# Altium schematic sheet Ti60-F225_Button_Board.SchDoc
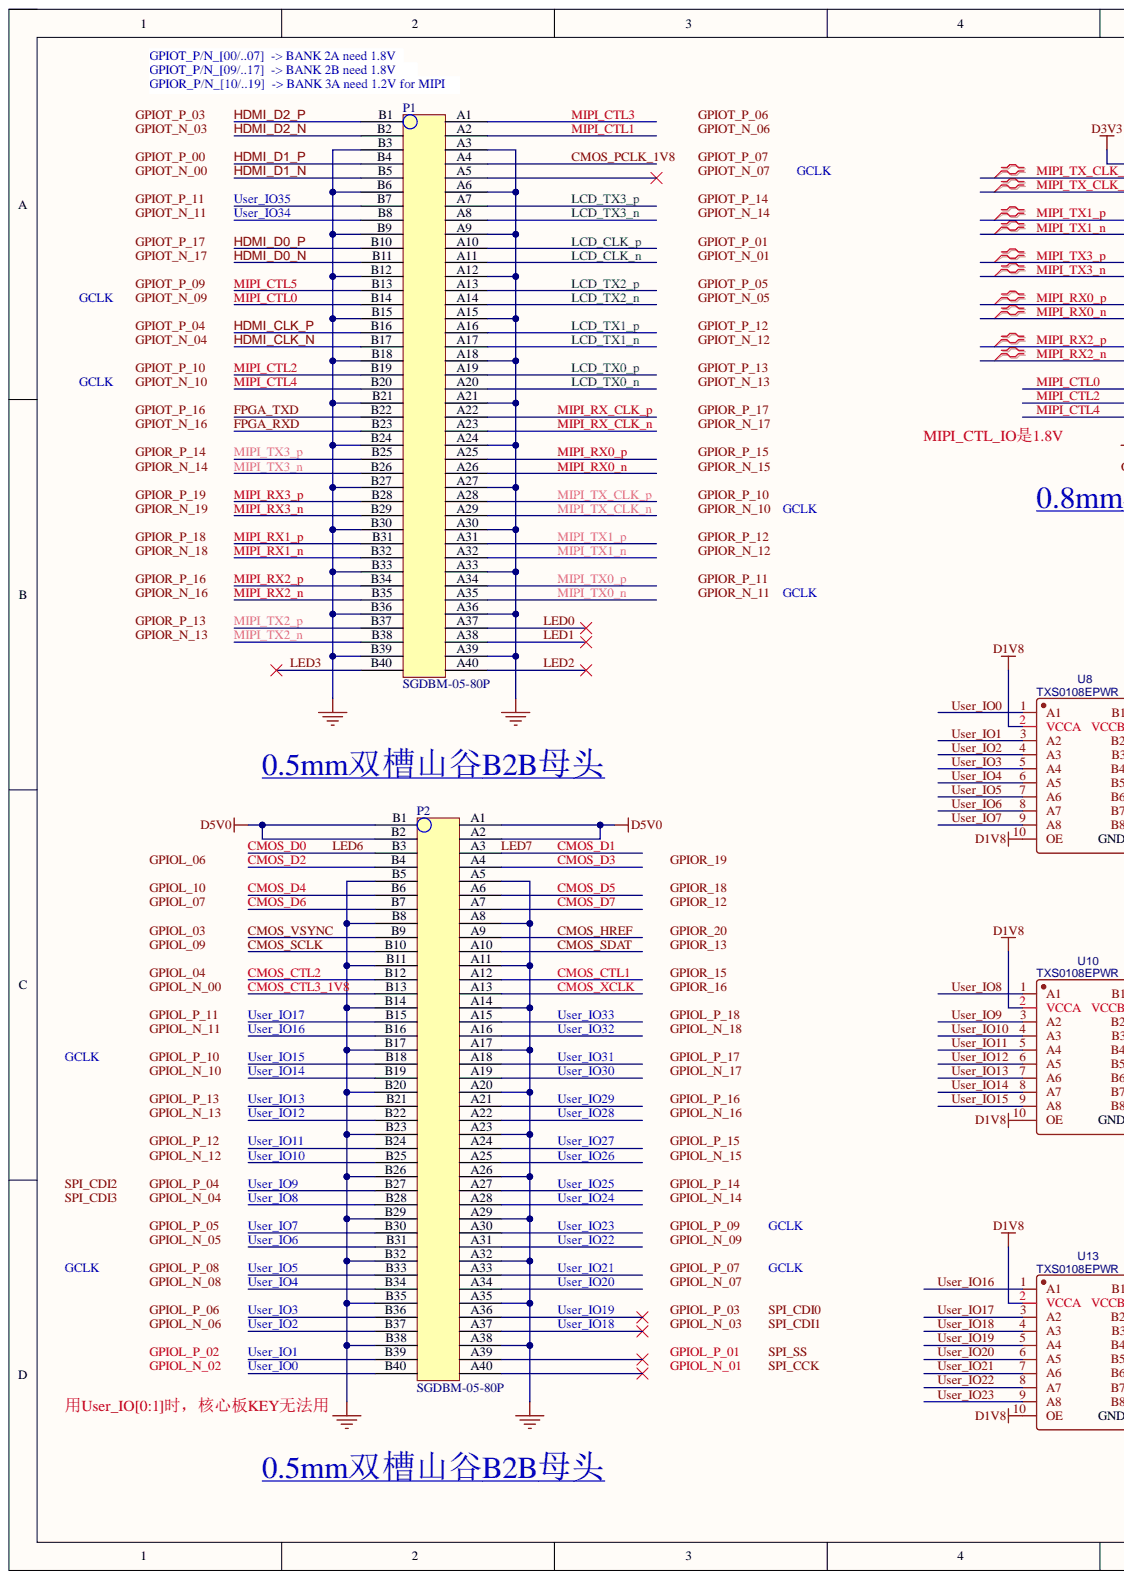
[diagram p0: Ti60-F225_Button_Board.SchDoc, left]
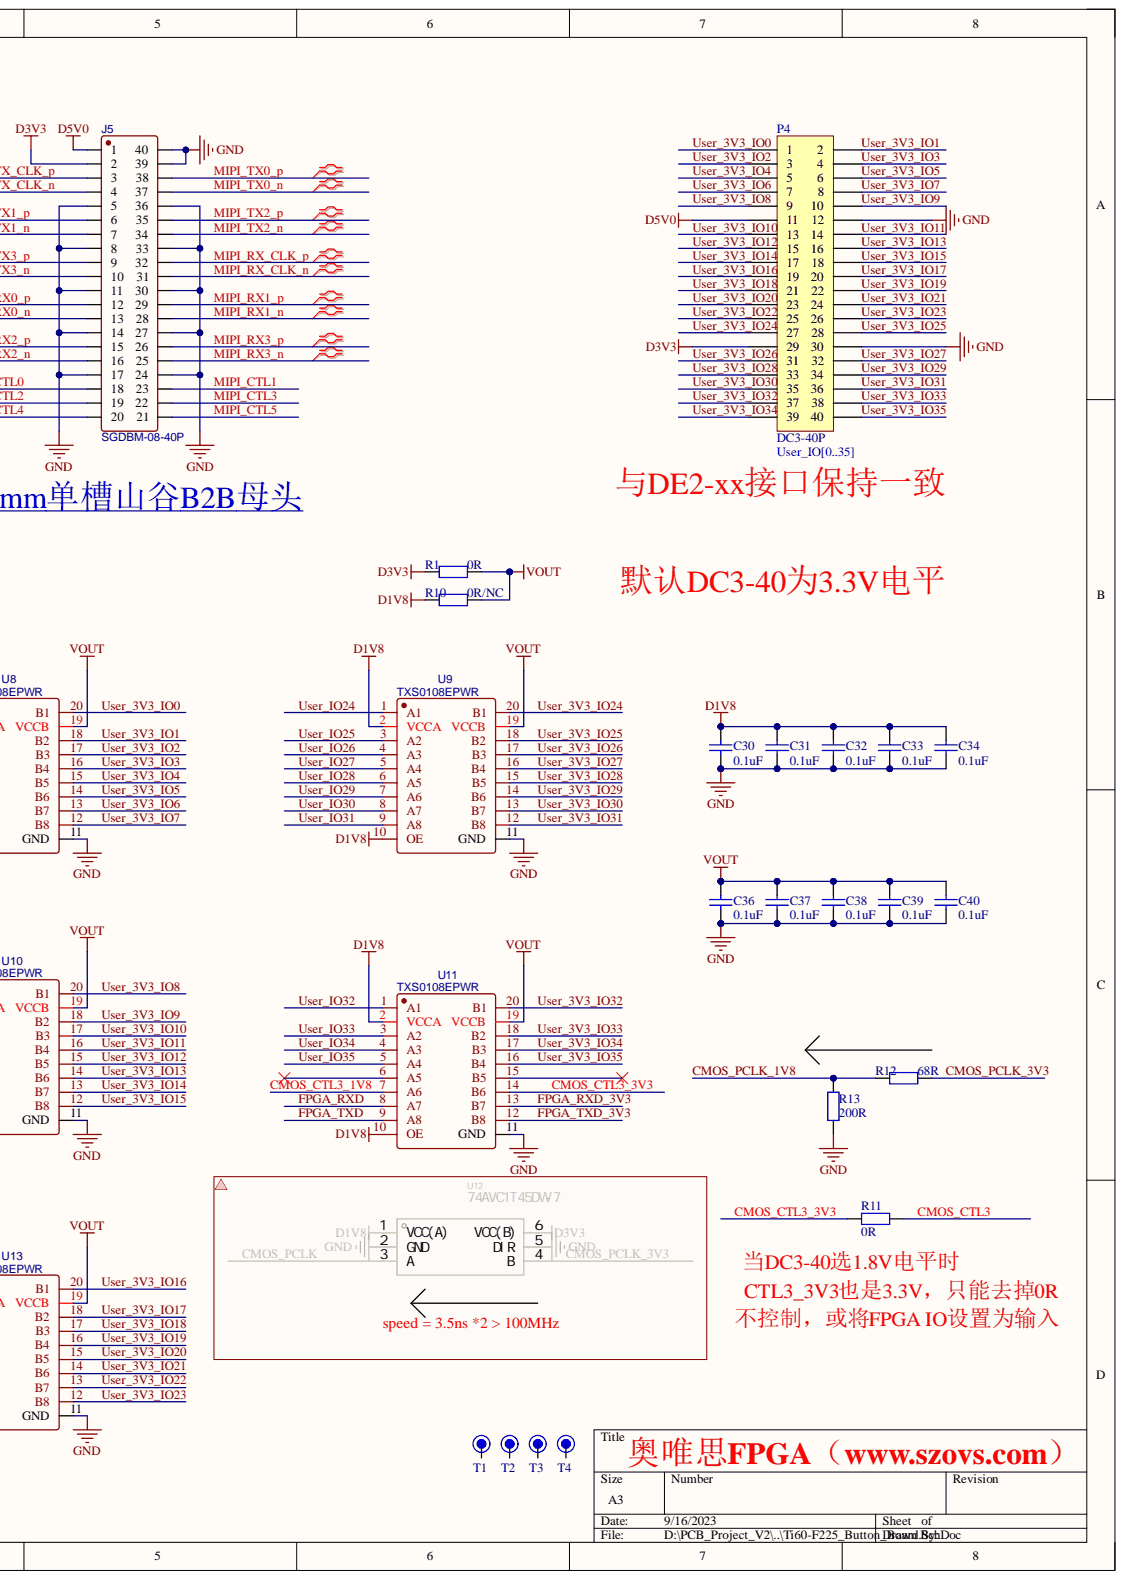
[diagram p1: Ti60-F225_Button_Board.SchDoc, right]

## Parts
C30  0.1uF  [R0402]
C31  0.1uF  [R0402]
C32  0.1uF  [R0402]
C33  0.1uF  [R0402]
C34  0.1uF  [R0402]
C36  0.1uF  [R0402]
C37  0.1uF  [R0402]
C38  0.1uF  [R0402]
C39  0.1uF  [R0402]
C40  0.1uF  [R0402]
J5  SGDBM-08-40P  [SGDBM-08-40P]
P1  SGDBM-05-80P  [P2P_0_5MM_80P]
P2  SGDBM-05-80P  [P2P_0_5MM_80P]
P4  DC3-40P  [DC3-40]
R1  0R  [R0603]
R10  0R/NC  [R0603]
R11  0R  [R0603]
R12  68R  [R0402]
R13  200R  [R0402]
T1  Pin_M3  [Pin_M3]  (value not drawn)
T2  Pin_M3  [Pin_M3]  (value not drawn)
T3  Pin_M3  [Pin_M3]  (value not drawn)
T4  Pin_M3  [Pin_M3]  (value not drawn)
U8  TXS0108EPWR  [TSSOP20]
U9  TXS0108EPWR  [TSSOP20]
U10  TXS0108EPWR  [TSSOP20]
U11  TXS0108EPWR  [TSSOP20]
U13  TXS0108EPWR  [TSSOP20]

## Nets
D1V8: C30.2 C31.2 C32.2 C33.2 C34.2 R10.2 U8.2(VCCA) U8.10(OE) U9.2(VCCA) U9.10(OE) U10.2(VCCA) U10.10(OE) U11.2(VCCA) U11.10(OE) U13.2(VCCA) U13.10(OE)
D3V3: J5.2 P4.29 R1.2
D5V0: J5.1 P2.A1 P2.A2 P2.B1 P2.B2 P4.11
GND: C30.1 C31.1 C32.1 C33.1 C34.1 C36.1 C37.1 C38.1 C39.1 C40.1 J5.5 J5.8 J5.11 J5.14 J5.17 J5.24 J5.27 J5.30 J5.33 J5.36 J5.39 J5.40 P1.A3 P1.A6 P1.A9 P1.A12 P1.A15 P1.A18 P1.A21 P1.A24 P1.A27 P1.A30 P1.A33 P1.A36 P1.A39 P1.B3 P1.B6 P1.B9 P1.B12 P1.B15 P1.B18 P1.B21 P1.B24 P1.B27 P1.B30 P1.B33 P1.B36 P1.B39 P2.A5 P2.A8 P2.A11 P2.A14 P2.A17 P2.A20 P2.A23 P2.A26 P2.A29 P2.A32 P2.A35 P2.A38 P2.B5 P2.B8 P2.B11 P2.B14 P2.B17 P2.B20 P2.B23 P2.B26 P2.B29 P2.B32 P2.B35 P2.B38 P4.12 P4.30 R13.2 U8.11(GND) U9.11(GND) U10.11(GND) U11.11(GND) U13.11(GND)
VOUT: C36.2 C37.2 C38.2 C39.2 C40.2 R1.1 R10.1 U8.19(VCCB) U9.19(VCCB) U10.19(VCCB) U11.19(VCCB) U13.19(VCCB)
CMOS_CTL1: P2.A12
CMOS_CTL2: P2.B12
CMOS_CTL3: R11.2
CMOS_CTL3_1V8: P2.B13 U11.7(A6)
CMOS_CTL3_3V3: R11.1 U11.14(B6)
CMOS_D0: P2.B3
CMOS_D1: P2.A3
CMOS_D2: P2.B4
CMOS_D3: P2.A4
CMOS_D4: P2.B6
CMOS_D5: P2.A6
CMOS_D6: P2.B7
CMOS_D7: P2.A7
CMOS_HREF: P2.A9
CMOS_PCLK_1V8: P1.A4 R12.2 R13.1
CMOS_PCLK_3V3: R12.1
CMOS_SCLK: P2.B10
CMOS_SDAT: P2.A10
CMOS_VSYNC: P2.B9
CMOS_XCLK: P2.A13
FPGA_RXD: P1.B23 U11.8(A7)
FPGA_RXD_3V3: U11.13(B7)
FPGA_TXD: P1.B22 U11.9(A8)
FPGA_TXD_3V3: U11.12(B8)
HDMI_CLK_N: P1.B17
HDMI_CLK_P: P1.B16
HDMI_D0_N: P1.B11
HDMI_D0_P: P1.B10
HDMI_D1_N: P1.B5
HDMI_D1_P: P1.B4
HDMI_D2_N: P1.B2
HDMI_D2_P: P1.B1
LCD_CLK_n: P1.A11
LCD_CLK_p: P1.A10
LCD_TX0_n: P1.A20
LCD_TX0_p: P1.A19
LCD_TX1_n: P1.A17
LCD_TX1_p: P1.A16
LCD_TX2_n: P1.A14
LCD_TX2_p: P1.A13
LCD_TX3_n: P1.A8
LCD_TX3_p: P1.A7
LED0: P1.A37
LED1: P1.A38
LED2: P1.A40
LED3: P1.B40
MIPI_CTL0: J5.18 P1.B14
MIPI_CTL1: J5.23 P1.A2
MIPI_CTL2: J5.19 P1.B19
MIPI_CTL3: J5.22 P1.A1
MIPI_CTL4: J5.20 P1.B20
MIPI_CTL5: J5.21 P1.B13
MIPI_RX0_n: J5.13 P1.A26
MIPI_RX0_p: J5.12 P1.A25
MIPI_RX1_n: J5.28 P1.B32
MIPI_RX1_p: J5.29 P1.B31
MIPI_RX2_n: J5.16 P1.B35
MIPI_RX2_p: J5.15 P1.B34
MIPI_RX3_n: J5.25 P1.B29
MIPI_RX3_p: J5.26 P1.B28
MIPI_RX_CLK_n: J5.31 P1.A23
MIPI_RX_CLK_p: J5.32 P1.A22
MIPI_TX0_n: J5.37 P1.A35
MIPI_TX0_p: J5.38 P1.A34
MIPI_TX1_n: J5.7 P1.A32
MIPI_TX1_p: J5.6 P1.A31
MIPI_TX2_n: J5.34 P1.B38
MIPI_TX2_p: J5.35 P1.B37
MIPI_TX3_n: J5.10 P1.B26
MIPI_TX3_p: J5.9 P1.B25
MIPI_TX_CLK_n: J5.4 P1.A29
MIPI_TX_CLK_p: J5.3 P1.A28
User_3V3_IO0: P4.1 U8.20(B1)
User_3V3_IO1: P4.2 U8.18(B2)
User_3V3_IO2: P4.3 U8.17(B3)
User_3V3_IO3: P4.4 U8.16(B4)
User_3V3_IO4: P4.5 U8.15(B5)
User_3V3_IO5: P4.6 U8.14(B6)
User_3V3_IO6: P4.7 U8.13(B7)
User_3V3_IO7: P4.8 U8.12(B8)
User_3V3_IO8: P4.9 U10.20(B1)
User_3V3_IO9: P4.10 U10.18(B2)
User_3V3_IO10: P4.13 U10.17(B3)
User_3V3_IO11: P4.14 U10.16(B4)
User_3V3_IO12: P4.15 U10.15(B5)
User_3V3_IO13: P4.16 U10.14(B6)
User_3V3_IO14: P4.17 U10.13(B7)
User_3V3_IO15: P4.18 U10.12(B8)
User_3V3_IO16: P4.19 U13.20(B1)
User_3V3_IO17: P4.20 U13.18(B2)
User_3V3_IO18: P4.21 U13.17(B3)
User_3V3_IO19: P4.22 U13.16(B4)
User_3V3_IO20: P4.23 U13.15(B5)
User_3V3_IO21: P4.24 U13.14(B6)
User_3V3_IO22: P4.25 U13.13(B7)
User_3V3_IO23: P4.26 U13.12(B8)
User_3V3_IO24: P4.27 U9.20(B1)
User_3V3_IO25: P4.28 U9.18(B2)
User_3V3_IO26: P4.31 U9.17(B3)
User_3V3_IO27: P4.32 U9.16(B4)
User_3V3_IO28: P4.33 U9.15(B5)
User_3V3_IO29: P4.34 U9.14(B6)
User_3V3_IO30: P4.35 U9.13(B7)
User_3V3_IO31: P4.36 U9.12(B8)
User_3V3_IO32: P4.37 U11.20(B1)
User_3V3_IO33: P4.38 U11.18(B2)
User_3V3_IO34: P4.39 U11.17(B3)
User_3V3_IO35: P4.40 U11.16(B4)
User_IO0: P2.B40 U8.1(A1)
User_IO1: P2.B39 U8.3(A2)
User_IO2: P2.B37 U8.4(A3)
User_IO3: P2.B36 U8.5(A4)
User_IO4: P2.B34 U8.6(A5)
User_IO5: P2.B33 U8.7(A6)
User_IO6: P2.B31 U8.8(A7)
User_IO7: P2.B30 U8.9(A8)
User_IO8: P2.B28 U10.1(A1)
User_IO9: P2.B27 U10.3(A2)
User_IO10: P2.B25 U10.4(A3)
User_IO11: P2.B24 U10.5(A4)
User_IO12: P2.B22 U10.6(A5)
User_IO13: P2.B21 U10.7(A6)
User_IO14: P2.B19 U10.8(A7)
User_IO15: P2.B18 U10.9(A8)
User_IO16: P2.B16 U13.1(A1)
User_IO17: P2.B15 U13.3(A2)
User_IO18: P2.A37 U13.4(A3)
User_IO19: P2.A36 U13.5(A4)
User_IO20: P2.A34 U13.6(A5)
User_IO21: P2.A33 U13.7(A6)
User_IO22: P2.A31 U13.8(A7)
User_IO23: P2.A30 U13.9(A8)
User_IO24: P2.A28 U9.1(A1)
User_IO25: P2.A27 U9.3(A2)
User_IO26: P2.A25 U9.4(A3)
User_IO27: P2.A24 U9.5(A4)
User_IO28: P2.A22 U9.6(A5)
User_IO29: P2.A21 U9.7(A6)
User_IO30: P2.A19 U9.8(A7)
User_IO31: P2.A18 U9.9(A8)
User_IO32: P2.A16 U11.1(A1)
User_IO33: P2.A15 U11.3(A2)
User_IO34: P1.B8 U11.4(A3)
User_IO35: P1.B7 U11.5(A4)
GCLK:
GPIOL_03:
GPIOL_04:
GPIOL_06:
GPIOL_07:
GPIOL_09:
GPIOL_10:
GPIOL_N_00:
GPIOL_N_01:
GPIOL_N_02:
GPIOL_N_03:
GPIOL_N_04:
GPIOL_N_05:
GPIOL_N_06:
GPIOL_N_07:
GPIOL_N_08:
GPIOL_N_09:
GPIOL_N_10:
GPIOL_N_11:
GPIOL_N_12:
GPIOL_N_13:
GPIOL_N_14:
GPIOL_N_15:
GPIOL_N_16:
GPIOL_N_17:
GPIOL_N_18:
GPIOL_P_01:
GPIOL_P_02:
GPIOL_P_03:
GPIOL_P_04:
GPIOL_P_05:
GPIOL_P_06:
GPIOL_P_07:
GPIOL_P_08:
GPIOL_P_09:
GPIOL_P_10:
GPIOL_P_11:
GPIOL_P_12:
GPIOL_P_13:
GPIOL_P_14:
GPIOL_P_15:
GPIOL_P_16:
GPIOL_P_17:
GPIOL_P_18:
GPIOR_12:
GPIOR_13:
GPIOR_15:
GPIOR_16:
GPIOR_18:
GPIOR_19:
GPIOR_20:
GPIOR_N_10:
GPIOR_N_11:
GPIOR_N_12:
GPIOR_N_13:
GPIOR_N_14:
GPIOR_N_15:
GPIOR_N_16:
GPIOR_N_17:
GPIOR_N_18:
GPIOR_N_19:
GPIOR_P_10:
GPIOR_P_11:
GPIOR_P_12:
GPIOR_P_13:
GPIOR_P_14:
GPIOR_P_15:
GPIOR_P_16:
GPIOR_P_17:
GPIOR_P_18:
GPIOR_P_19:
GPIOT_N_00:
GPIOT_N_01:
GPIOT_N_03:
GPIOT_N_04:
GPIOT_N_05:
GPIOT_N_06:
GPIOT_N_07:
GPIOT_N_09:
GPIOT_N_10:
GPIOT_N_11:
GPIOT_N_12:
GPIOT_N_13:
GPIOT_N_14:
GPIOT_N_16:
GPIOT_N_17:
GPIOT_P_00:
GPIOT_P_01:
GPIOT_P_03:
GPIOT_P_04:
GPIOT_P_05:
GPIOT_P_06:
GPIOT_P_07:
GPIOT_P_09:
GPIOT_P_10:
GPIOT_P_11:
GPIOT_P_12:
GPIOT_P_13:
GPIOT_P_14:
GPIOT_P_16:
GPIOT_P_17:
SPI_CCK:
SPI_CDI0:
SPI_CDI1:
SPI_CDI2:
SPI_CDI3:
SPI_SS:

Not connected: P1 A5; P2 A39 A40; T1 1; T2 1; T3 1; T4 1; U11 6(A5) 15(B5)

## Library data of the parts
C30-C34 C36-C40 = CAP: Component Kind: Standard; Library Name: Crazy_PCB.SchLib
J5 = P2P_0.8mm_40P: BOM_Manufacturer: Wcon; BOM_Manufacturer Part: 3617-P040-047G3R01; Contributor: LCSC; BOM_JLCPCB Part Class: Extended Part; BOM_Supplier: LCSC; BOM_Supplier Part: C843172; Component Kind: Standard; Library Name: Crazy_PCB.SchLib; spicePre: C; spiceSymbolName: 3617-P040-047G3R01
P4 = Header 20X2: DC3-10P 接插件; Component Kind: Standard; LatestRevisionDate: 17-Jul-2002; LatestRevisionNote: Re-released for DXP Platform.; Library Name: Miscellaneous Connectors.IntLib; Signal Integrity: Connector; Publisher: Altium Limited
R1 R10-R13 = RES: Resistor; Value: 33R; Component Kind: Standard; Library Name: Crazy_PCB.SchLib; Published: 33R; Revision: July-2002: Re-released for DXP Platform.; Publisher: Altium Limited
U8-U11 U13 = TXS0108EQPWRQ1: BOM_Manufacturer: TI(德州仪器); Contributor: LCSC; BOM_JLCPCB Part Class: Extended Part; BOM_Manufacturer Part: TXS0108EQPWRQ1; BOM_Supplier: LCSC; BOM_Supplier Part: C1021790; Component Kind: Standard; spicePre: U; spiceSymbolName: TXS0108EQPWRQ1
P1 P2 = SGDBM-05-80P: Component Kind: Standard; Library Name: my_sch_Lib.SchLib
T1-T4 = Pin_M3: Component Kind: Standard; Library Name: Crazy_PCB.SchLib
